# Altium schematic sheet Portable Speaker.SchDoc("Portable Speaker")
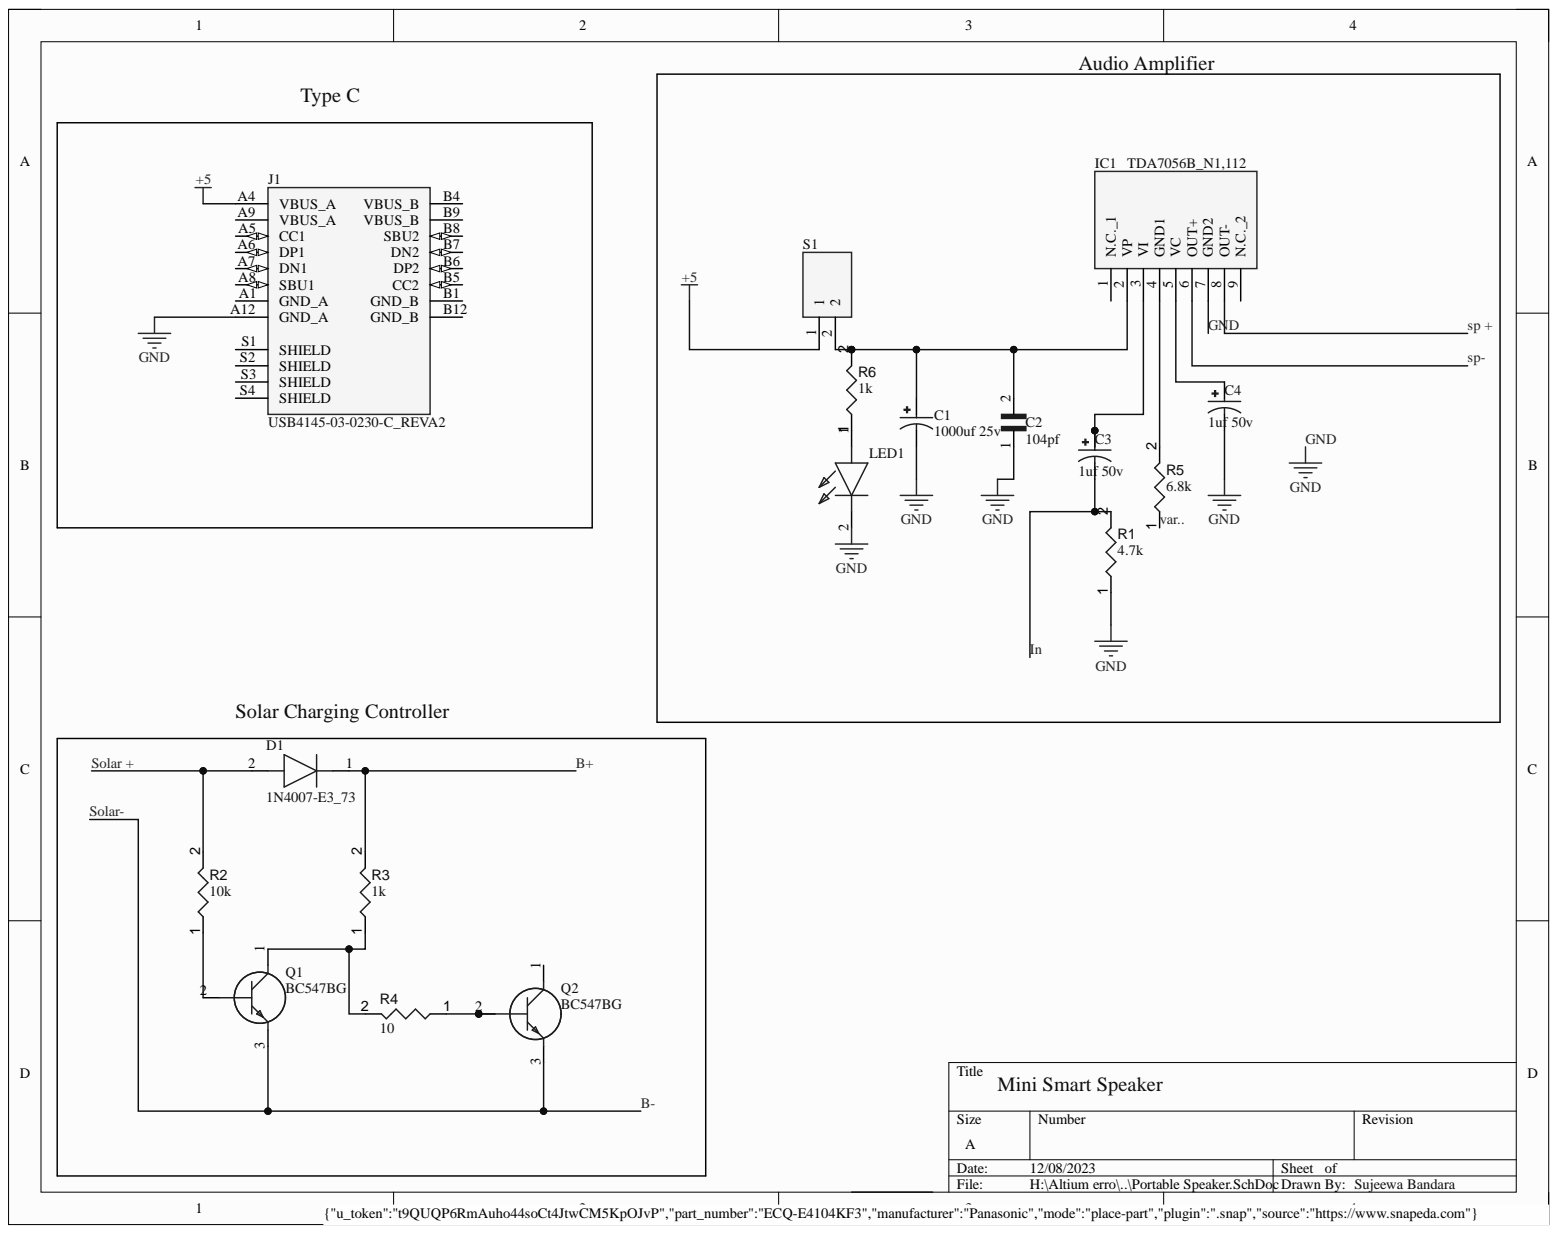
[diagram p0: Portable Speaker.SchDoc]

## Parts
C1  1000uf 25v  [CAPPRD500W60D1250H2500]
C2  104pf  [CAPRR500W60L1200T630H1700]
C3  1uf 50v  [CAPPRD500W50D500H1100]
C4  1uf 50v  [CAPPRD500W50D500H1100]
D1  1N4007-E3_73  [DIOAD1405W86L465D235]
IC1  TDA7056B_N1,112  [TDA7056BN1112]
J1  USB4145-03-0230-C_REVA2  [GCT_USB4145-03-0230-C_REVA2]
LED1  (no value)  [C5SMFRJECT0W0BB2]
Q1  BC547BG  [TO-92_(TO-226)]
Q2  BC547BG  [TO-92_(TO-226)]
R1  4.7k  [RESA60-630X240]
R2  value 10k  [RESA60-630X240]  (hidden comment: 4.7k)
R3  value 1k  [RESA60-630X240]
R4  value 10  [RESA60-630X240]
R5  value 6.8k  [RESA60-630X240]
R6  value 1k  [RESA60-630X240]
S1  10KB012  [10KB012]  (value not drawn)

## Nets
+5: J1.A4(VBUS_A) S1.1
B+: D1.1 R3.2
B-: Q1.3 Q2.3
GND: C1.N C2.1 C4.N IC1.7(GND2) J1.A12(GND_A) LED1.2 R1.1
In: C3.N R1.2
Solar +: D1.2 R2.2
sp +: IC1.8(OUT-)
sp-: IC1.6(OUT+)
var..: R5.1
NetC1_P: C1.P C2.2 IC1.2(VP) R6.2 S1.2
NetC3_P: C3.P IC1.3(VI)
NetC4_P: C4.P IC1.5(VC)
NetIC1_4: IC1.4(GND1) R5.2
NetLED1_1: LED1.1 R6.1
NetQ1_1: Q1.1 R3.1 R4.2
NetQ1_2: Q1.2 R2.1
NetQ2_2: Q2.2 R4.1

Not connected: IC1 1(N.C._1) 9(N.C._2); J1 A1(GND_A) A5(CC1) A6(DP1) A7(DN1) A8(SBU1) A9(VBUS_A) B1(GND_B) B4(VBUS_B) B5(CC2) B6(DP2) B7(DN2) B8(SBU2) B9(VBUS_B) B12(GND_B) S1(SHIELD) S2(SHIELD) S3(SHIELD) S4(SHIELD); Q2 1

## Library data of the parts
C1 = EEUTA1E102LB: CAP ALUM 1000UF 20% 25V RADIAL; MF: Panasonic; Availability: No availability data; Check_prices: https://www.snapeda.com/parts/EEUTA1E102LB/Panasonic/view-part/5789306/?ref=eda; Component Kind: Standard; Library Name: EEUTA1E102LB.SchLib; MP: EEUTA1E102LB; Package: RADIAL-2 Panasonic; Price: None; SnapEDA_Link: https://www.snapeda.com/parts/EEUTA1E102LB/Panasonic/view-part/5789306/?ref=snap
C2 = ECQE4104KF3: Availability: Unavailable; Component Kind: Standard; Library Name: ECQE4104KF3.SchLib; MP: ECQE4104KF3; Package: None; Price: None
C3 C4 = EEUEB1H1R0SB: CAP ALUM 1UF 20% 50V RADIAL; MF: Panasonic Electronic Components; MP: EEU-EB1H1R0SB; Availability: Not in stock; Check_prices: https://www.snapeda.com/parts/EEU-EB1H1R0SB/Panasonic/view-part/6222296/?ref=eda; Component Kind: Standard; Library Name: EEUEB1H1R0SB.SchLib; Package: RADIAL-2 Panasonic; Price: None; SnapEDA_Link: https://www.snapeda.com/parts/EEU-EB1H1R0SB/Panasonic/view-part/6222296/?ref=snap
D1 = 1N4007-E3_73: Diode; Manufacturer_Name: Vishay; Manufacturer_Part_Number: 1N4007-E3/73; Arrow Part Number: 1N4007-E3/73; Arrow Price/Stock: https://www.arrow.com/en/products/1n4007-e373/vishay?region=europe; Component Kind: Standard; Datasheet Link: https://mouser.componentsearchengine.com/Datasheets/2/1N4007-E3_73.pdf; Mouser Part Number: 625-1N4007-E3/73; Mouser Price/Stock: https://www.mouser.co.uk/ProductDetail/Vishay-General-Semiconductor/1N4007-E3-73?qs=N4vtoAxH%2FSqT3Ng3e4K0Gw%3D%3D
IC1 = TDA7056B_N1,112: Integrated Circuit; Manufacturer_Name: NXP; Manufacturer_Part_Number: TDA7056B/N1,112; Component Kind: Standard; Datasheet Link: https://www.nxp.com/docs/en/data-sheet/TDA7056B.pdf; Height: 18.5mm; Mouser Part Number: 771-TDA7056BU; Mouser Price/Stock: https://www.mouser.co.uk/ProductDetail/NXP-Semiconductors/TDA7056B-N1112?qs=LOCUfHb8d9vUmeWwSdC8oA%3D%3D
LED1 = C5SMF-RJE-CT0W0BB2: LED; Manufacturer_Name: CREE LED; Arrow Part Number: C5SMF-RJE-CT0W0BB2; Arrow Price/Stock: https://www.arrow.com/en/products/c5smf-rje-ct0w0bb2/cree-led?region=nac; Component Kind: Standard; Datasheet Link: https://www.mouser.com/datasheet/2/723/C5SMF_RJF_RJE_GJF_GJE_BJF_BJE_1240-2256911.pdf; Height: 8.75mm; Manufacturer_Part_Number: C5SMF-RJE-CT0W0BB2; Mouser Part Number: 941-C5SMFRJECT0W0BB2; Mouser Price/Stock: https://www.mouser.co.uk/ProductDetail/Cree-LED/C5SMF-RJE-CT0W0BB2?qs=1sbE9T7hb3bz%2FXMFB8lsHw%3D%3D
Q1 Q2 = BC547BG: Transistor BJT NPN; Manufacturer_Name: onsemi; Arrow Part Number: BC547BG; Arrow Price/Stock: http://www.arrow.com/en/products/bc547bg/on-semiconductor; Component Kind: Standard; Datasheet Link: https://datasheet.datasheetarchive.com/originals/distributors/Datasheets-23/DSA-455923.pdf; Height: 5.33mm; Manufacturer_Part_Number: BC547BG; Mouser Part Number: N/A; Mouser Price/Stock: http://www.mouser.com/Search/ProductDetail.aspx?qs=NSmgnqlvnz5NIKIycfR5CQ%3d%3d
R1-R6 = CMP-009-00057-4: Axial Resistor, 47.5 KOhm, +/- 1%, 0.25 W, -55 to 155 degC, 2-Pin THD, RoHS, Bulk; Manufacturer: Yageo; Power: 250mW; Voltage Rating: 250V; Case/Package: Axial; Component Kind: Standard; Datasheet URL: http://www.yageo.com/documents/recent/Yageo%20LR_MFR_2013.pdf; Datasheet Version: Apr-13; Manufacturer URL: http://www.yageo.com/; Max Operating Temperature: 155°C; Min Operating Temperature: -55°C; Mounting Technology: TH; Package Description: 2-Pin Axial Lead, Dia 2.4 mm, Body Length 6.3 mm; Package Quantity: 200; Package Reference: MFR-25; Packaging: Bulk; RoHS Compliant: True; Tolerance: 1%; Library Name: University of jaffna
  R1: Value: 4.7k
S1 = 10KB012: Switch; Manufacturer_Name: Mountain Switch; Component Kind: Standard; Datasheet Link: https://www.mouser.it/datasheet/2/221/MS-100496-1174041.pdf; Height: 12.62mm; Manufacturer_Part_Number: 10KB012; Mouser Part Number: 10KB012; Mouser Price/Stock: https://www.mouser.co.uk/ProductDetail/Mountain-Switch/10KB012?qs=JTMHOUw%252B%2FhnWAc6Brfqn7A%3D%3D
J1 = USB4145-03-0230-C_REVA2: Availability: Unavailable; Component Kind: Standard; Library Name: USB4145-03-0230-C_REVA2.SchLib; MP: USB4145-03-0230-C_REVA2; Package: None; Price: None
